# Altium schematic sheet PowerSchem.SchDoc(PowerSchem) (continued)
## Library data of the parts (continued)
U7 = LT3029EDE#PBF: LDO Regulator Pos 1.215V to 19.5V 0.5A 16-Pin DFN EP; Manufacturer: Analog Devices; Manufacturer Lifecycle Status: PRODUCTION; Dropout Voltage: 300mV; Max Junction Temperature (Tj): 125°C; Max Supply Voltage: 20V; Min Current Limit: 520mA; Min Input Voltage: 1.8V; Number of Channels: 1; Number of Outputs: 2; Polarity: Positive; Case/Package: DFN; Category: Voltage Regulators - Linear; Component Kind: Standard; ComponentLink1Description: https://datasheet.octopart.com:443/LT3029EDE%23PBF-Analog-Devices-datasheet-26569571.pdf?src-supplier=Upverter; ComponentLink1URL: https://datasheet.octopart.com:443/LT3029EDE%23PBF-Analog-Devices-datasheet-26569571.pdf?src-supplier=Upverter; ComponentLink2Description: https://datasheet.octopart.com:443/LT3029EDE%23PBF-Linear-Technology-datasheet-14357712.pdf?src-supplier=Upverter; ComponentLink2URL: https://datasheet.octopart.com:443/LT3029EDE%23PBF-Linear-Technology-datasheet-14357712.pdf?src-supplier=Upverter; ComponentLink3Description: https://octopart.com:443/opatz8j6/c1?t=qU3BsmE-v0Jwl642rgpeURTQc-MXELaRr_u9bXcgPBo5ECKnDr3SpqE_3OMW_c6eN0TJL6QtafTYwh-e5BLV3jFR2ItgjyRp6tBzPAhLxT7P6tVmFvJLAgY53LcrqwnWSr5pWjSewhzY24Ys5rC48ACBzjQlnxL-TzEJhgISzWrpJN502vrcjSldoLkOj7vuCMX0JStzoG2PEs55GreG1EwIeYH94fj7WwkgIHGyqyf9kv0SFsDCi5tyFECI8SeNJnQ7q4tfy9dkpJCLuotSVZSYa3oW6a2l-Z_ufdKKvwgUJGNOlxNS&src-supplier=Analog+Devices; ComponentLink3URL: https://octopart.com:443/opatz8j6/c1?t=qU3BsmE-v0Jwl642rgpeURTQc-MXELaRr_u9bXcgPBo5ECKnDr3SpqE_3OMW_c6eN0TJL6QtafTYwh-e5BLV3jFR2ItgjyRp6tBzPAhLxT7P6tVmFvJLAgY53LcrqwnWSr5pWjSewhzY24Ys5rC48ACBzjQlnxL-TzEJhgISzWrpJN502vrcjSldoLkOj7vuCMX0JStzoG2PEs55GreG1EwIeYH94fj7WwkgIHGyqyf9kv0SFsDCi5tyFECI8SeNJnQ7q4tfy9dkpJCLuotSVZSYa3oW6a2l-Z_ufdKKvwgUJGNOlxNS&src-supplier=Analog+Devices; ComponentLink4Description: https://datasheet.octopart.com:443/LT3029EDE%23PBF-Linear-Technology-datasheet-10850731.pdf?src-supplier=element14; ComponentLink4URL: https://datasheet.octopart.com:443/LT3029EDE%23PBF-Linear-Technology-datasheet-10850731.pdf?src-supplier=element14; ComponentLink5Description: https://datasheet.octopart.com:443/LT3029EDE%23PBF-Linear-Technology-datasheet-8618308.pdf?src-supplier=Farnell; ComponentLink5URL: https://datasheet.octopart.com:443/LT3029EDE%23PBF-Linear-Technology-datasheet-8618308.pdf?src-supplier=Farnell; ComponentLink6Description: https://datasheet.octopart.com:443/LT3029EDE%23PBF-Linear-Technology-datasheet-15919048.pdf?src-supplier=iiiC; ComponentLink6URL: https://datasheet.octopart.com:443/LT3029EDE%23PBF-Linear-Technology-datasheet-15919048.pdf?src-supplier=iiiC; ComponentLink7Description: https://sigma.octopart.com:443/57695931/technical_drawing/Linear-Technology-LT3029EDE%23PBF.pdf?src-supplier=Linear+Technology; ComponentLink7URL: https://sigma.octopart.com:443/57695931/technical_drawing/Linear-Technology-LT3029EDE%23PBF.pdf?src-supplier=Linear+Technology; ComponentLink8Description: http://sigma.octopart.com:80/conflict_mineral_statement/Analog-Devices-company-33.pdf?src-supplier=Compliance+Statements; ComponentLink8URL: http://sigma.octopart.com:80/conflict_mineral_statement/Analog-Devices-company-33.pdf?src-supplier=Compliance+Statements; ComponentLink9Description: https://octopart.com:443/opatz8j6/c1?t=jok27i-MNVRa9Dhq3FL0hwYfwlJRJZZccGuij5R6W92fxOwJKto8gIFTQQU1_Qf6pCH9HzFn8tf5JlfnvOrx4lLu1QsoSLtkIIxLIXTtAKwdNr2p9E3J2ROxr0s_W8Qr-Va1h5kiAcmwp9inFR6XOGv1kB1-MQCQAJLa-fDnp0VPh61KDA8mv_nec21JJPxi_IfFDVqPuUuo0Ry6VAqLNr5ask5fSzhZy12HbVMTxniUTf5vj1O5FvGuPDqI4Z2D1zCs8dIHTLor02t5hL5wcyzORuYLeUu_VOs4HDqlp9_1QiT72dus&src-supplier=Compliance+Statements; ComponentLink9URL: https://octopart.com:443/opatz8j6/c1?t=jok27i-MNVRa9Dhq3FL0hwYfwlJRJZZccGuij5R6W92fxOwJKto8gIFTQQU1_Qf6pCH9HzFn8tf5JlfnvOrx4lLu1QsoSLtkIIxLIXTtAKwdNr2p9E3J2ROxr0s_W8Qr-Va1h5kiAcmwp9inFR6XOGv1kB1-MQCQAJLa-fDnp0VPh61KDA8mv_nec21JJPxi_IfFDVqPuUuo0Ry6VAqLNr5ask5fSzhZy12HbVMTxniUTf5vj1O5FvGuPDqI4Z2D1zCs8dIHTLor02t5hL5wcyzORuYLeUu_VOs4HDqlp9_1QiT72dus&src-supplier=Compliance+Statements; ComponentLink10Description: https://octopart.com:443/opatz8j6/c1?t=xZc9spvEmlXWbGFw6zM-g55hKd-QdbiqtKjjcrr-w0Kx8hv7C-sfCHjP5KW1KG2fxyCag-HExi8vtK3PezTbE0GiReyOtjb4sG0qETXDXeIHRl3zZg0sJxVE3uBObalYl51sC8EzC0muuJBCAh2veJsRSgJG31bcWWLhWB5JqwrMcO7TvvVCHmppxKw9zvvNA50FdVU0ooSkQ7_CGxTDW0Ur6-GovsRjGthdlqfoONi5pXSBHrtXuuBBzZ7tBYXHWYJRt9IbJmjBzAiN0l2re-ef8YOWJ_vAuDK4kFbMgU64zt7-ScJwCA&src-supplier=Analog+Devices; ComponentLink10URL: https://octopart.com:443/opatz8j6/c1?t=xZc9spvEmlXWbGFw6zM-g55hKd-QdbiqtKjjcrr-w0Kx8hv7C-sfCHjP5KW1KG2fxyCag-HExi8vtK3PezTbE0GiReyOtjb4sG0qETXDXeIHRl3zZg0sJxVE3uBObalYl51sC8EzC0muuJBCAh2veJsRSgJG31bcWWLhWB5JqwrMcO7TvvVCHmppxKw9zvvNA50FdVU0ooSkQ7_CGxTDW0Ur6-GovsRjGthdlqfoONi5pXSBHrtXuuBBzZ7tBYXHWYJRt9IbJmjBzAiN0l2re-ef8YOWJ_vAuDK4kFbMgU64zt7-ScJwCA&src-supplier=Analog+Devices; ComponentLink11Description: https://octopart.com:443/opatz8j6/c1?t=Q6OggpSahTjzGk0DXWHPHtzBqGGwzkBCdT1iEUb96Ul3VEVIEkeXBqS-XliSXzcK4xOGyJN0u4Ev30alFnabA2ZA0p9ngarIAWp9ATXW4zZPhBa4cL-s9-vQ5sKn9vkm9Ic3n7MEBOs7O8MhHApdaXyf5xTkPmFuV920Cuur0SnPm0cNx-4l_zWfRolHdYZfB_mE9tIFp-iWxjd8zNkvBBDh5jz_UJaOBSojPYvpE2JHy43SK-jWlu-vybI5l5NZ4JQ4CYCd4xqtvVZ9B6DNei3fCnAMGaaoGNv7wniK6ES7tiez8MUYZQ&src-supplier=Compliance+Statements; ComponentLink11URL: https://octopart.com:443/opatz8j6/c1?t=Q6OggpSahTjzGk0DXWHPHtzBqGGwzkBCdT1iEUb96Ul3VEVIEkeXBqS-XliSXzcK4xOGyJN0u4Ev30alFnabA2ZA0p9ngarIAWp9ATXW4zZPhBa4cL-s9-vQ5sKn9vkm9Ic3n7MEBOs7O8MhHApdaXyf5xTkPmFuV920Cuur0SnPm0cNx-4l_zWfRolHdYZfB_mE9tIFp-iWxjd8zNkvBBDh5jz_UJaOBSojPYvpE2JHy43SK-jWlu-vybI5l5NZ4JQ4CYCd4xqtvVZ9B6DNei3fCnAMGaaoGNv7wniK6ES7tiez8MUYZQ&src-supplier=Compliance+Statements; Current - Output 2: 500mA; Height: 800µm; Library Name: Power.SchLib; Lifecycle Status: Production; Manufacturer Part Number: LT3029EDE#PBF; Max Operating Temperature: 125°C; Min Operating Temperature: -40°C; Min Supply Voltage: 1.8V; Mounting Technology: Surface Mount; Number of Regulators: 2; Output Current: 500mA; Output Voltage: 19.5V; Pins: 16; Pricing: 1=9.36, 10=7.28, 25=6.93, 50=6.57, 182=6.22, 273=6, 546=5.89 (USD); REACH SVHC: No; RoHS: Compliant; Schedule B: 8542390000, 8542390000|8542390000|8542390000|8542390000|8542390000; Stock: 92; Supplier: Newark; Supplier 1: Newark; Supplier Part Number: LT3029EDE#PBF; Supplier Part Number 1: LT3029EDE#PBF; Voltage - Output 1: 19.5V; Voltage - Output 2: 19.5V
